# Altium schematic sheet Esquemático SensorTemperatura.SchDoc("Esquemático SensorTemperatura")
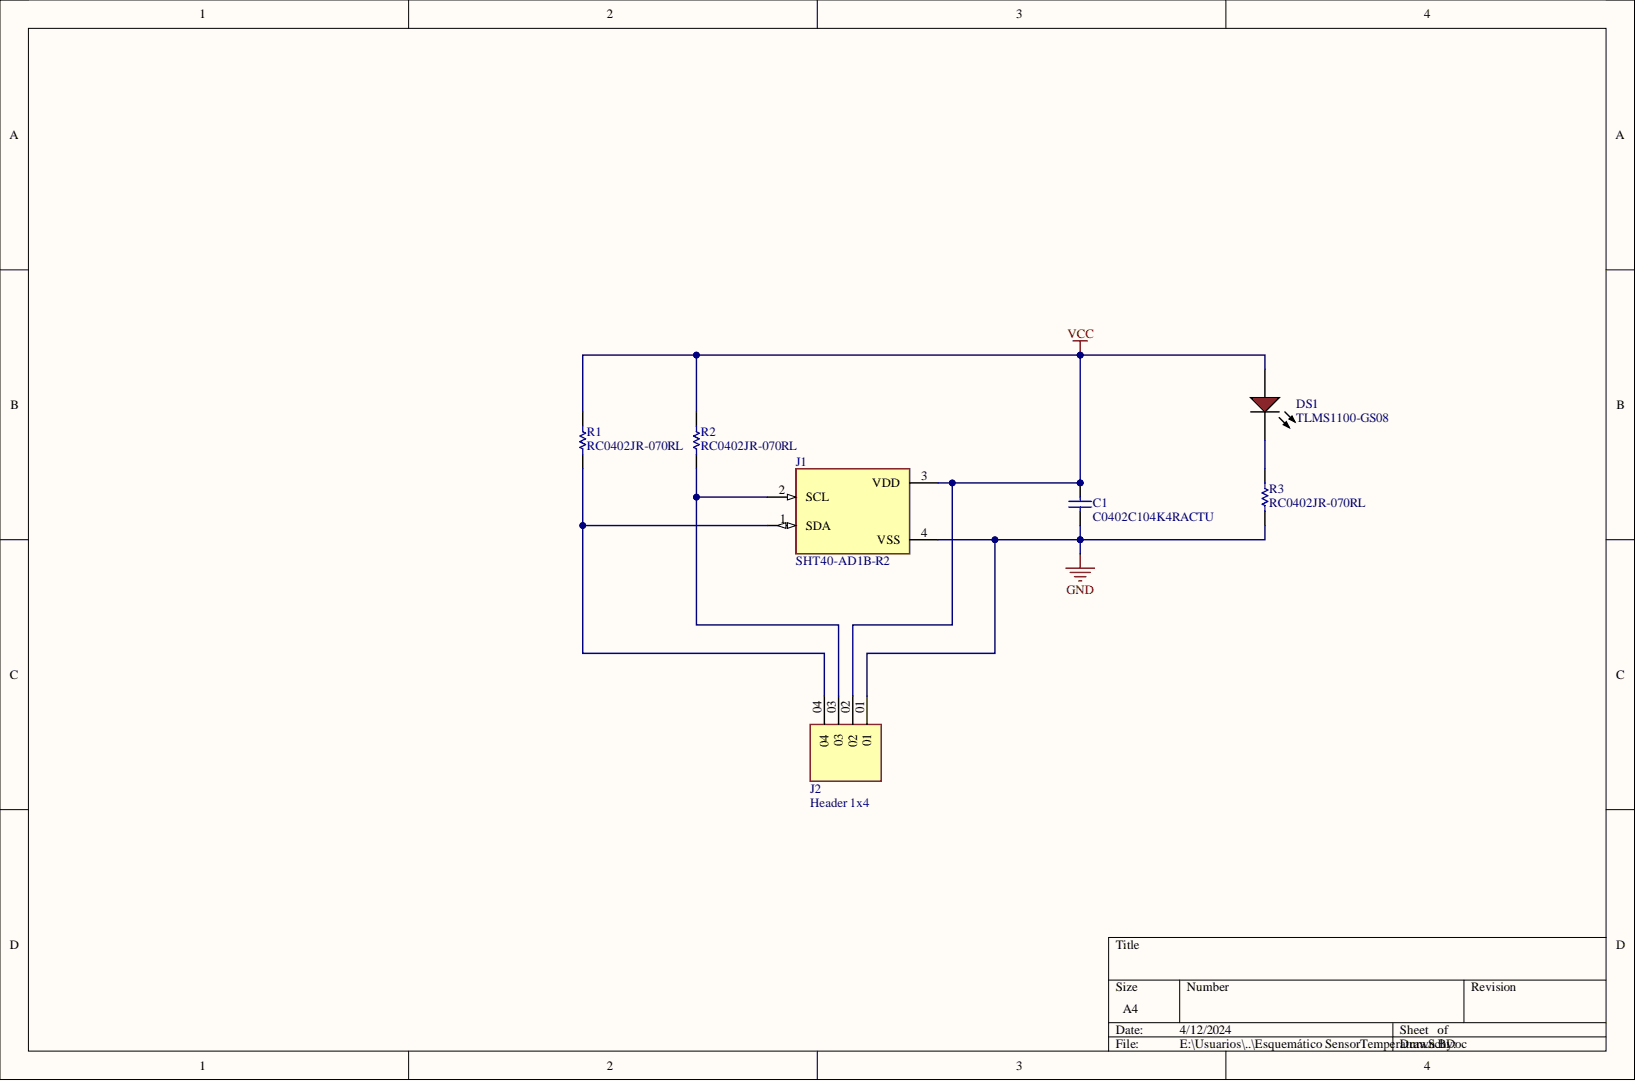
[diagram p0: Esquemático SensorTemperatura.SchDoc]

## Parts
C1  C0402C104K4RACTU  [Capacitor]
DS1  TLMS1100-GS08  [LED 0603]
J1  SHT40-AD1B-R2  [SHT40]
J2  Header 1x4  [Header 1x4]
R1  RC0402JR-070RL  [Resistor]
R2  RC0402JR-070RL  [Resistor]
R3  RC0402JR-070RL  [Resistor]

## Nets
GND: C1.1 J1.4(VSS) J2.01 R3.1
VCC: C1.2 DS1.1 J1.3(VDD) J2.02 R1.2 R2.2
NetDS1_2: DS1.2 R3.2
NetJ1_1: J1.1(SDA) J2.04 R1.1
NetJ1_2: J1.2(SCL) J2.03 R2.1

## Library data of the parts
C1 = Capacitor: Manufacturer: Kemet; Component Kind: Standard; Part Number: C0402C104K4RACTU; Library Name: Librería Esquematicos.SchLib
DS1 = LED 0603: LED, RED, 2.1 V, -40 to 100 degC, 2-Pin SMD (0603), RoHS, Tape and Reel; Capacitance: 12 pF; Resistance: 100000000000 Ohm; Tolerance: 5%; Type (Capacitors): C0G; Voltage Rating: 50 V; Case Code (Imperial): 0603; Case Code (Metric): 1608; Case/Package: 0603; Component Kind: Standard; ComponentLink1Description: Manufacturer URL; ComponentLink1URL: http://www.vishay.com/; ComponentLink2Description: Datasheet; ComponentLink2URL: http://www.vishay.com/docs/83173/tlmb1100.pdf; DatasheetVersion: Rev. 2.7, 03/2016; Height: 0.8 mm; Length: 1.6 mm; Max Operating Temperature: 125degC; Min Operating Temperature: -55degC; Mount: Surface Mount; Package Quantity: 4000; PackageDescription: 2-Pin Surface Mount Device, Body 1.6 x 0.8 mm; PackageReference: TLMX1100; Packaging: Tape and Reel; Pins: 2; Radiation Hardening: No; REACH SVHC: No SVHC; RoHSCompliant: True; Voltage Rating (DC): 50 V; Width: 0.8 mm; Library Name: Librería Esquematicos.SchLib
J1 = SHT40-AD1B-R2: Humidity/Temperature Sensor Digital Serial (I2C) 4-Pin DFN EP T/R; MF: Sensirion AG; Availability: In Stock; Check_prices: https://www.snapeda.com/parts/SHT40-AD1B-R2/NSI/view-part/?ref=eda; Component Kind: Standard; MP: SHT40-AD1B-R2; Package: None; Price: None; Purchase-URL: https://pricing.snapeda.com/search/part/SHT40-AD1B-R2/?ref=eda; SnapEDA_Link: https://www.snapeda.com/parts/SHT40-AD1B-R2/NSI/view-part/?ref=snap; Library Name: Librería Esquematicos.SchLib
J2 = Header 1x4: <a href="https://pricing.snapeda.com/parts/TSW-104-07-G-S/Samtec/view-part?ref=eda">Check availability</a>; MP: altium_native_batch; Availability: Unavailable; Component Kind: Standard; Package: None; Price: None; Library Name: Librería Esquematicos.SchLib
R1-R3 = Resistor: Manufacturer: Yageo / Phycomp; Component Kind: Standard; Part Number: RC0402JR-070RL; Library Name: Librería Esquematicos.SchLib
